# Altium schematic sheet HF_AMP_DRIVER.SchDoc
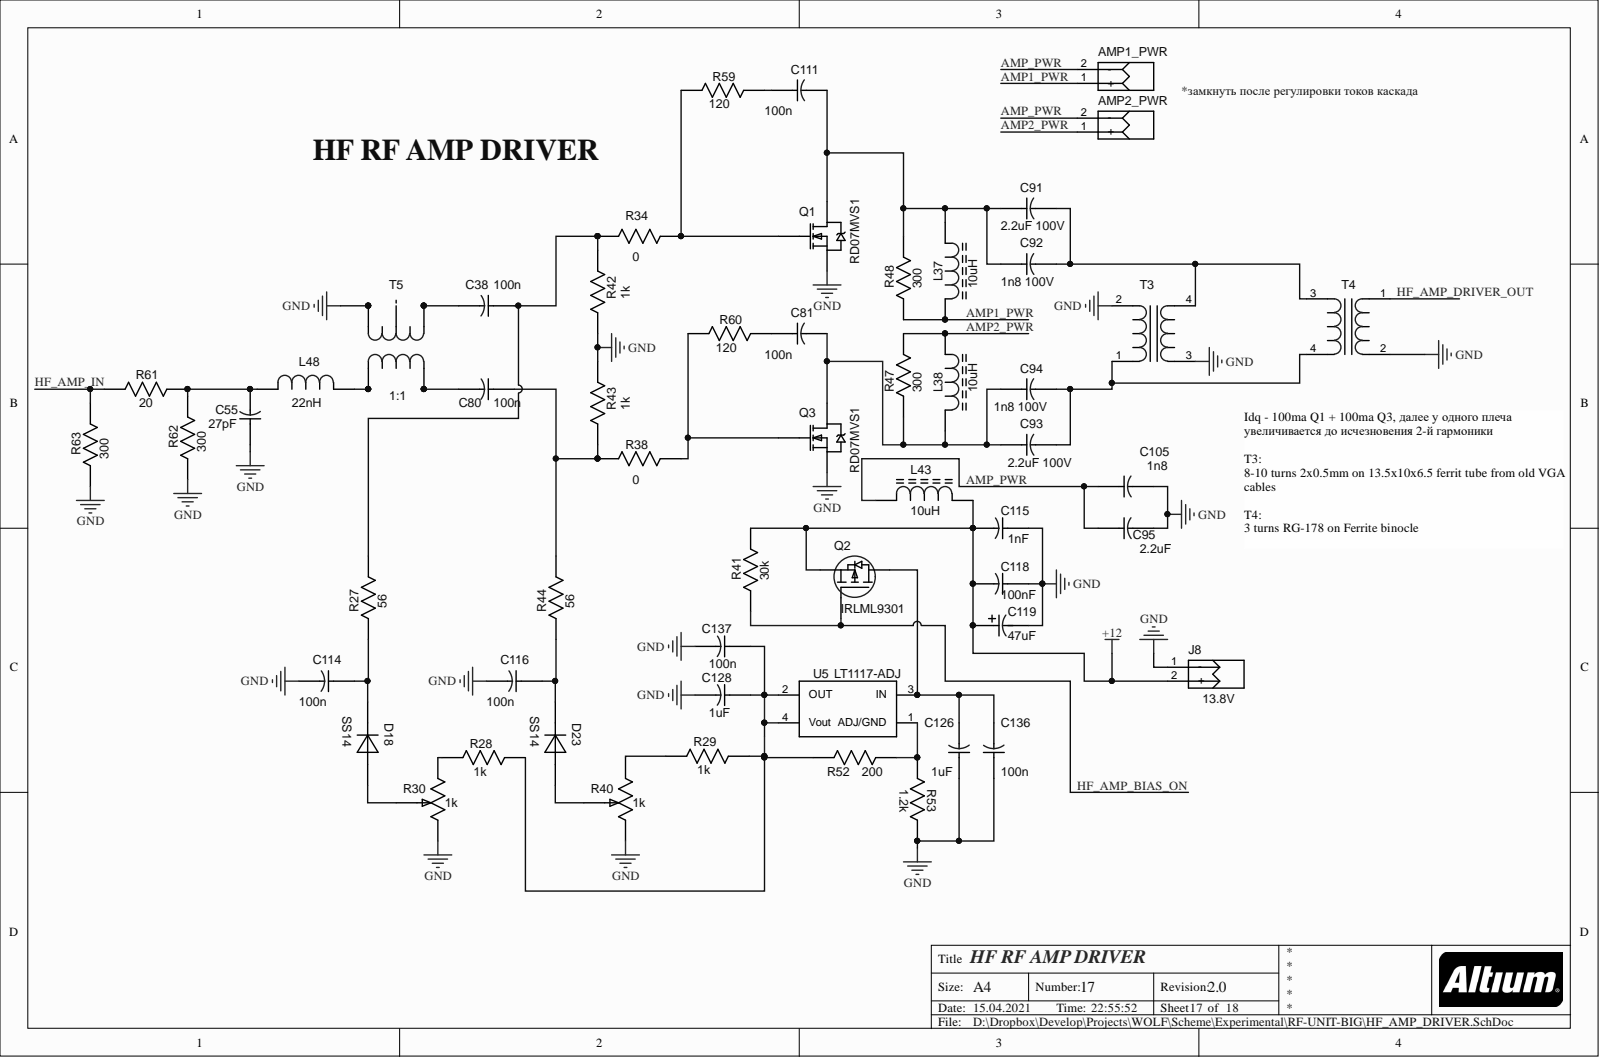
[diagram p0: HF_AMP_DRIVER.SchDoc]

## Parts
AMP1_PWR  51022-0200  [51022-0200]  (value not drawn)
AMP2_PWR  51022-0200  [51022-0200]  (value not drawn)
C38  100n  [CAP_0805]
C55  27pF  [CAP_0805]
C80  100n  [CAP_0805]
C81  100n  [CAP_1206]
C91  2.2uF 100V  [CAP_1206]
C92  1n8 100V  [CAP_1206]
C93  2.2uF 100V  [CAP_1206]
C94  1n8 100V  [CAP_1206]
C95  2.2uF  [CAP_1206]
C105  1n8  [CAP_1206]
C111  100n  [CAP_1206]
C114  100n  [CAP_0805]
C115  1nF  [CAP_0805]
C116  100n  [CAP_0805]
C118  100nF  [CAP_0805]
C119  47uF  [CAPAE_6.6x6.6h5.4]
C126  1uF  [CAP_0805]
C128  1uF  [CAP_0805]
C136  100n  [CAP_0805]
C137  100n  [CAP_0805]
D18  SS14  [SS14]
D23  SS14  [SS14]
J8  13.8V  [1986717-2]
L37  10uH  [IND_FERRITE_CORE]
L38  10uH  [IND_FERRITE_CORE]
L43  10uH  [IND_FERRITE_CORE]
L48  22nH  [IND_0805]
Q1  RD07MVS1  [RD07MVS1]
Q2  IRLML9301  [SOT23]
Q3  RD07MVS1  [RD07MVS1]
R27  56  [RES_0805]
R28  1k  [RES_0805]
R29  1k  [RES_0805]
R30  value 1k  [306J]  (hidden comment: ADJ-1k)
R34  0  [RES_1206]
R38  0  [RES_1206]
R40  value 1k  [306J]  (hidden comment: ADJ-1k)
R41  30k  [RES_0805]
R42  1k  [RES_0805]
R43  1k  [RES_0805]
R44  56  [RES_0805]
R47  300  [RES_1206]
R48  300  [RES_1206]
R52  200  [RES_0805]
R53  1.2k  [RES_0805]
R59  120  [RES_1206]
R60  120  [RES_1206]
R61  20  [RES_1206]
R62  300  [RES_1206]
R63  300  [RES_1206]
T3  8T M2000HM 10*6*5  [Rutrof]  (value not drawn)
T4  TRANSF_ICORE  [TRANSF_ICORE]  (value not drawn)
T5  1:1  [TRANSF_ACORE2]
U5  value LT1117-ADJ  [LT1117IST-ADJ]  (hidden comment: LT1117IST-ADJ)

## Nets
+12: C115.2 C118.2 C119.1 J8.2 L43.2 Q2.2 R41.2
GND: C55.1 C95.2 C105.2 C114.2 C115.1 C116.2 C118.1 C119.2 C126.1 C128.2 C136.1 C137.2 J8.1 Q1.2 Q3.2 R30.1 R40.1 R42.1 R43.2 R53.2 R62.1 R63.1 T3.2 T3.3 T4.2 T5.3 T5.9
AMP1_PWR: AMP1_PWR.1 L37.2 R48.1
AMP2_PWR: AMP2_PWR.1 L38.1 R47.2
AMP_PWR: AMP1_PWR.2 AMP2_PWR.2 C95.1 C105.1 L43.1
HF_AMP_BIAS_ON: Q2.1 R41.1
HF_AMP_DRIVER_OUT: T4.1
HF_AMP_IN: R61.1 R63.2
NetC38_1: C38.1 R27.2 R34.1 R42.2
NetC38_2: C38.2 T5.1 T5.7
NetC55_2: C55.2 L48.1 R61.2 R62.2
NetC80_1: C80.1 R38.1 R43.1 R44.2
NetC80_2: C80.2 T5.6 T5.10
NetC81_1: C81.1 R60.2
NetC81_2: C81.2 C93.1 C94.1 L38.2 Q3.1 R47.1
NetC91_1: C91.1 C92.1 C111.2 L37.1 Q1.1 R48.2
NetC91_2: C91.2 C92.2 T3.4 T4.3
NetC93_2: C93.2 C94.2 T3.1 T4.4
NetC111_1: C111.1 R59.2
NetC114_1: C114.1 D18.1 R27.1
NetC116_1: C116.1 D23.1 R44.1
NetC126_2: C126.2 C136.2 Q2.3 U5.3(IN)
NetC128_1: C128.1 C137.1 R28.2 R29.2 R52.1 U5.2(OUT) U5.4(Vout)
NetD18_2: D18.2 R30.2
NetD23_2: D23.2 R40.2
NetL48_2: L48.2 T5.4 T5.11
NetQ1_3: Q1.3 R34.2 R59.1
NetQ3_3: Q3.3 R38.2 R60.1
NetR28_1: R28.1 R30.3
NetR29_1: R29.1 R40.3
NetR52_2: R52.2 R53.1 U5.1(ADJ/GND)

Not connected: T5 2 8

## Library data of the parts
C38 C55 C80 C114-C116 C118 C126 C128 C136 C137 = CAP_0805: Component Kind: Standard; Description: CAP_0805
  C114 C116 C136 C137: Value: 100n
  C115: Value: 1nF
  C118: Value: 100nF
  C126 C128: Value: 1uF
C81 C91-C95 C105 C111 = CAP_1206: Component Kind: Standard; Description: CAP_1206
  C91 C93: Value: 2.2uF 100V
  C92 C94: Value: 1n8 100V
C119 = CAPAE_6.6x6.6h5.4: Component Kind: Standard; Description: CAPAE_6.6x6.6h5.4; Value: 47uF
J8 = 1986717-2: JX2; Component Kind: Standard; Value: 13.8V
L37 L38 L43 = IND_FERRITE_CORE: Inductor; Component Kind: Standard; Value: 10uH
L48 = IND_0805: Component Kind: Standard; Description: IND_0805
Q2 = MOSFET_ENH_PD: MOSFET; Component Kind: Standard; Value: IRLML9301
R27-R29 R41-R44 R52 R53 = RES_0805: Component Kind: Standard; Description: RES_0805
  R27 R44: Value: 56
  R28 R29 R42 R43: Value: 1k
  R41: Value: 30k
  R52: Value: 200
  R53: Value: 1.2k
R30 R40 = 306J: Component Kind: Standard; Description: 306J
R34 R38 R47 R48 R59-R63 = RES_1206: Component Kind: Standard; Description: RES_1206
  R47 R48: Value: 300
T3 = TRANSF_ICORE: Transformer; Component Kind: Standard
T5 = TRANSF_ACORE2: Component Kind: Standard; Description: 1:1; Library Name: RF-UNIT_1.SCHLIB; Value: 1:1
AMP1_PWR AMP2_PWR = 51022-0200: Component Kind: Standard; Description: 51022-0200
D18 D23 = SS14: Component Kind: Standard; Description: SS14; Value: SS14
Q1 Q3 = RD07MVS1: Component Kind: Standard; Description: RD07MVS1; Value: RD07MVS1
T4 = TRANSF_ICORE: Component Kind: Standard; Description: TRANSF_ICORE; Library Name: RF-UNIT-BIG.SchLib
U5 = LT1117IST-ADJ: Component Kind: Standard; Description: LT1117IST-ADJ
